# Altium schematic sheet Geiger.SchDoc
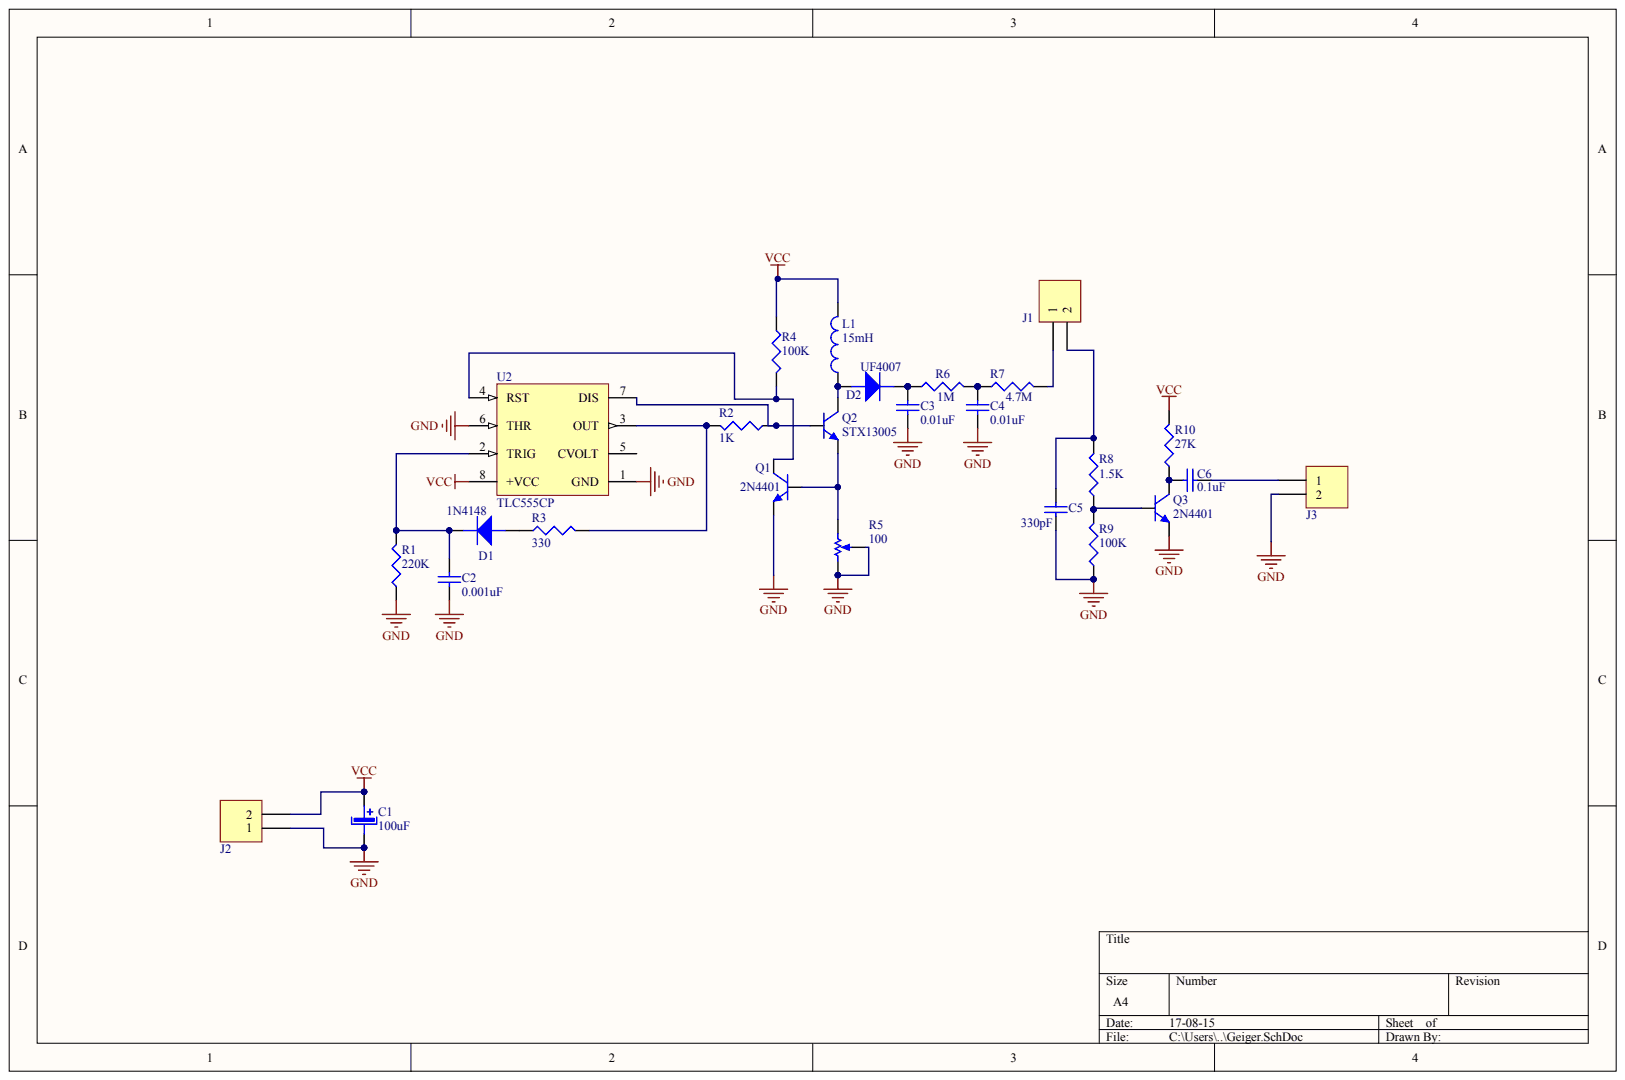
[diagram p0: Geiger.SchDoc]

## Parts
C1  value 100uF  (hidden comment: Cond pol axial)
C2  value 0.001uF  (hidden comment: Condensateur)
C3  value 0.01uF  (hidden comment: Condensateur)
C4  value 0.01uF  (hidden comment: Condensateur)
C5  value 330pF  (hidden comment: Condensateur)
C6  value 0.1uF  (hidden comment: Condensateur)
D1  value 1N4148  (hidden comment: Diode)
D2  Type: UF4007  (hidden comment: Diode)
J1  Connecteur 2  (value not drawn)
J2  Connecteur 2  (value not drawn)
J3  Connecteur 2  (value not drawn)
L1  value 15mH  (hidden comment: Inductance)
Q1  value 2N4401  (hidden comment: NPN)
Q2  value STX13005  (hidden comment: NPN)
Q3  value 2N4401  (hidden comment: NPN)
R1  value 220K  (hidden comment: Résistance)
R2  value 1K  (hidden comment: Résistance)
R3  value 330  (hidden comment: Résistance)
R4  value 100K  (hidden comment: Résistance)
R5  Potentiomètre  (value not drawn)
R6  value 1M  (hidden comment: Résistance)
R7  value 4.7M  (hidden comment: Résistance)
R8  value 1.5K  (hidden comment: Résistance)
R9  value 100K  (hidden comment: Résistance)
R10  value 27K  (hidden comment: Résistance)
U2  TLC555CP

## Nets
GND: C1.2 C2.1 C3.2 C4.2 C5.2 J2.1 J3.2 Q1.1 Q3.1 R1.1 R5.1 R5.3 R9.1 U2.1(GND) U2.6(THR)
VCC: C1.1 J2.2 L1.2 R4.1 R10.2 U2.8(+VCC)
NetC2_2: C2.2 D1.2 R1.2 U2.2(TRIG)
NetC3_1: C3.1 D2.2 R6.1
NetC4_1: C4.1 R6.2 R7.1
NetC5_1: C5.1 J1.2 R8.2
NetC6_1: C6.1 Q3.3 R10.1
NetC6_2: C6.2 J3.1
NetD1_1: D1.1 R3.1
NetD2_1: D2.1 L1.1 Q2.3
NetJ1_1: J1.1 R7.2
NetQ1_2: Q1.2 Q2.1 R5.2
NetQ1_3: Q1.3 R4.2 U2.4(RST)
NetQ2_2: Q2.2 R2.1 U2.7(DIS)
NetQ3_2: Q3.2 R8.1 R9.2
NetR2_2: R2.2 R3.2 U2.3(OUT)

Not connected: U2 5(CVOLT)

## Library data of the parts
C1 = (no symbol name): Type: Condensateur polarisé couché; Designer: ECAM 2004
D1 = (no symbol name): Type: Diode standard; Designer: ECAM 2003
Q1-Q3 = (no symbol name): Type: Transistor Bipolaire NPN; Designer: ECAM 2002
R5 = (no symbol name): Set Position: 100; Type: Résistance variable; Designer: ECAM 2002
U2 = (no symbol name): PartNumber: LM555CN; Manufacturer: National Semiconductor; PackageTopMarking: NSUZXYTTE#*LM*555CN; PowerWise: N; CompType: Analog IC; Published: 4-Dec-2008; DatasheetVersion: Jul-2006; PackageVersion: revG, May-2006; PackageReference: N08E; PackageDescription: MDIP, .365 x .250 x .130in, 8 Lead, .100in Pitch; Supplier 1: Farnell; Supplier Part Number 1: 9488235; RoHS: N; PinCount: 8; Code_JEDEC: MS-001-BA; ComponentLink1Description: LM555 Datasheet; ComponentLink1URL: http://www.national.com/ds/LM/LM555.pdf; ComponentLink2Description: LM555 Web Page; ComponentLink2URL: http://www.national.com/pf/LM/LM555.html; ComponentLink3Description: N08E Package Page; ComponentLink3URL: http://www.national.com/packaging/folders/n08e.html; Publisher: Altium Limited
C2-C6 D2 J1-J3 L1 R1-R4 R6-R10 = (no symbol name)
  C2-C6: Supplier: Farnell; Designer: ECAM 2004
  J1-J3: Type: Connecteur à vis
  R1-R4 R6-R10: Type: Résistance standard
  D2 L1: Designer: ECAM 2003
  J1-J3 R1-R4 R6-R10: Designer: ECAM 2005
